# Altium schematic sheet Driver.SchDoc
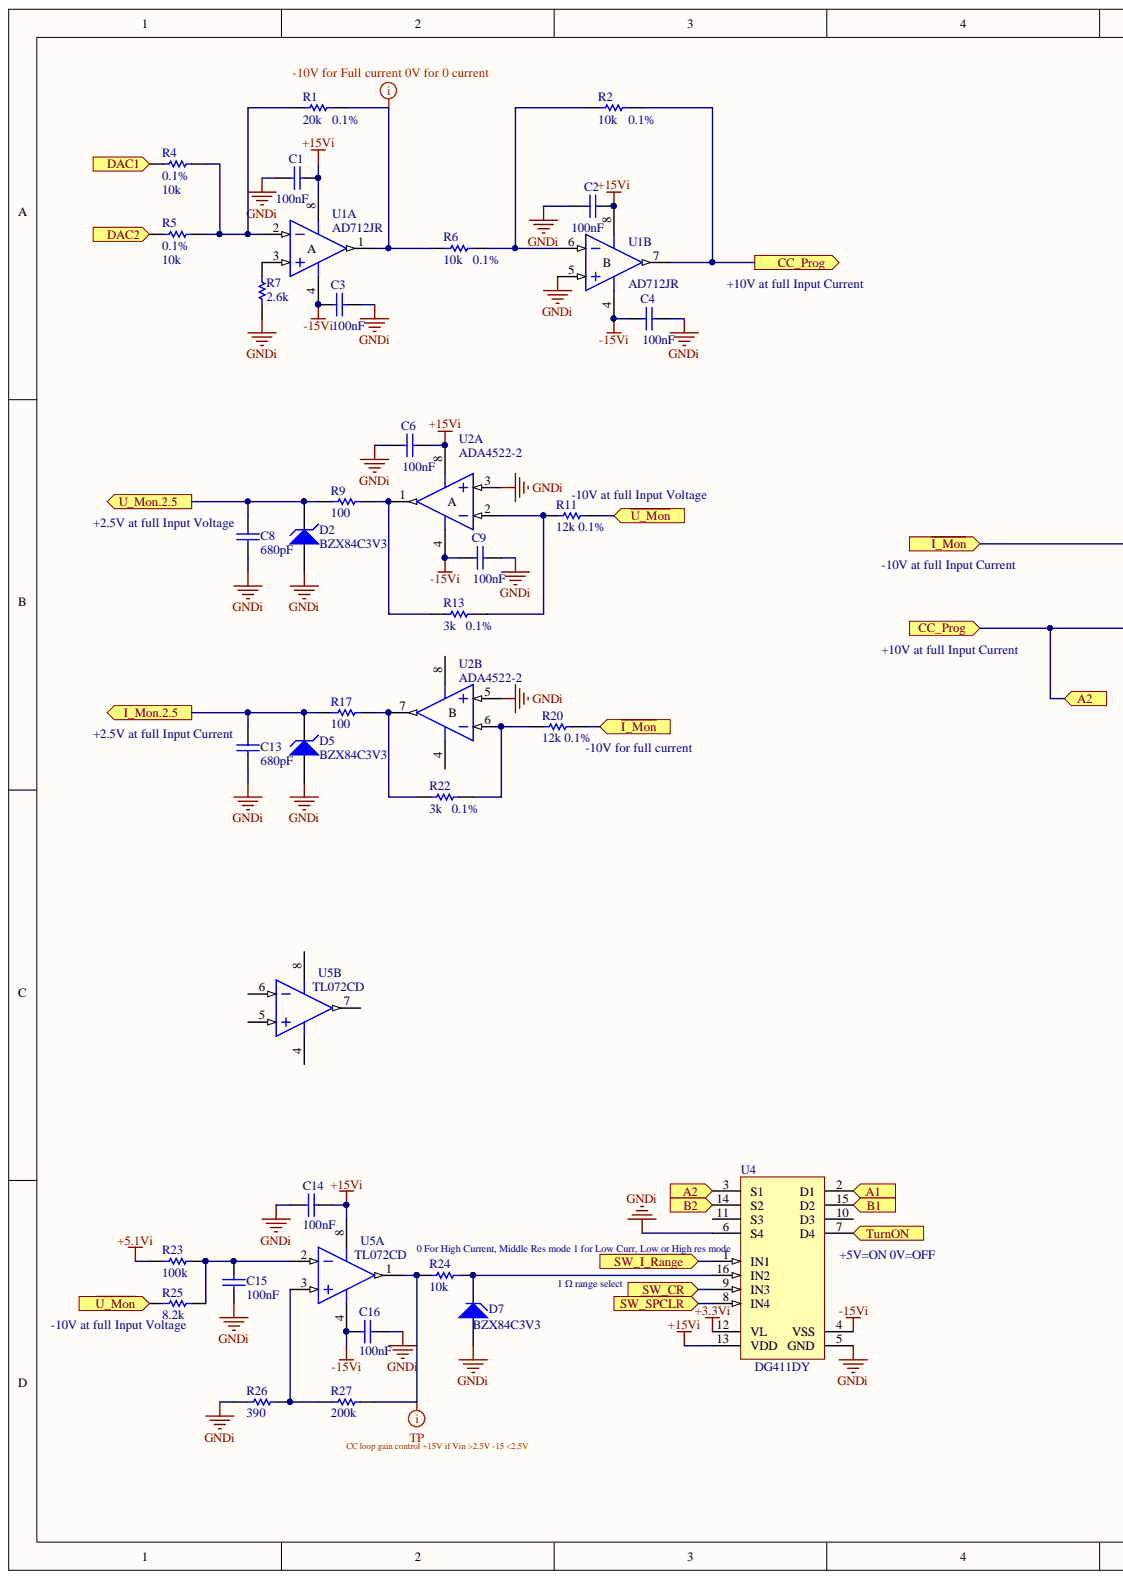
[diagram p0: Driver.SchDoc, left]
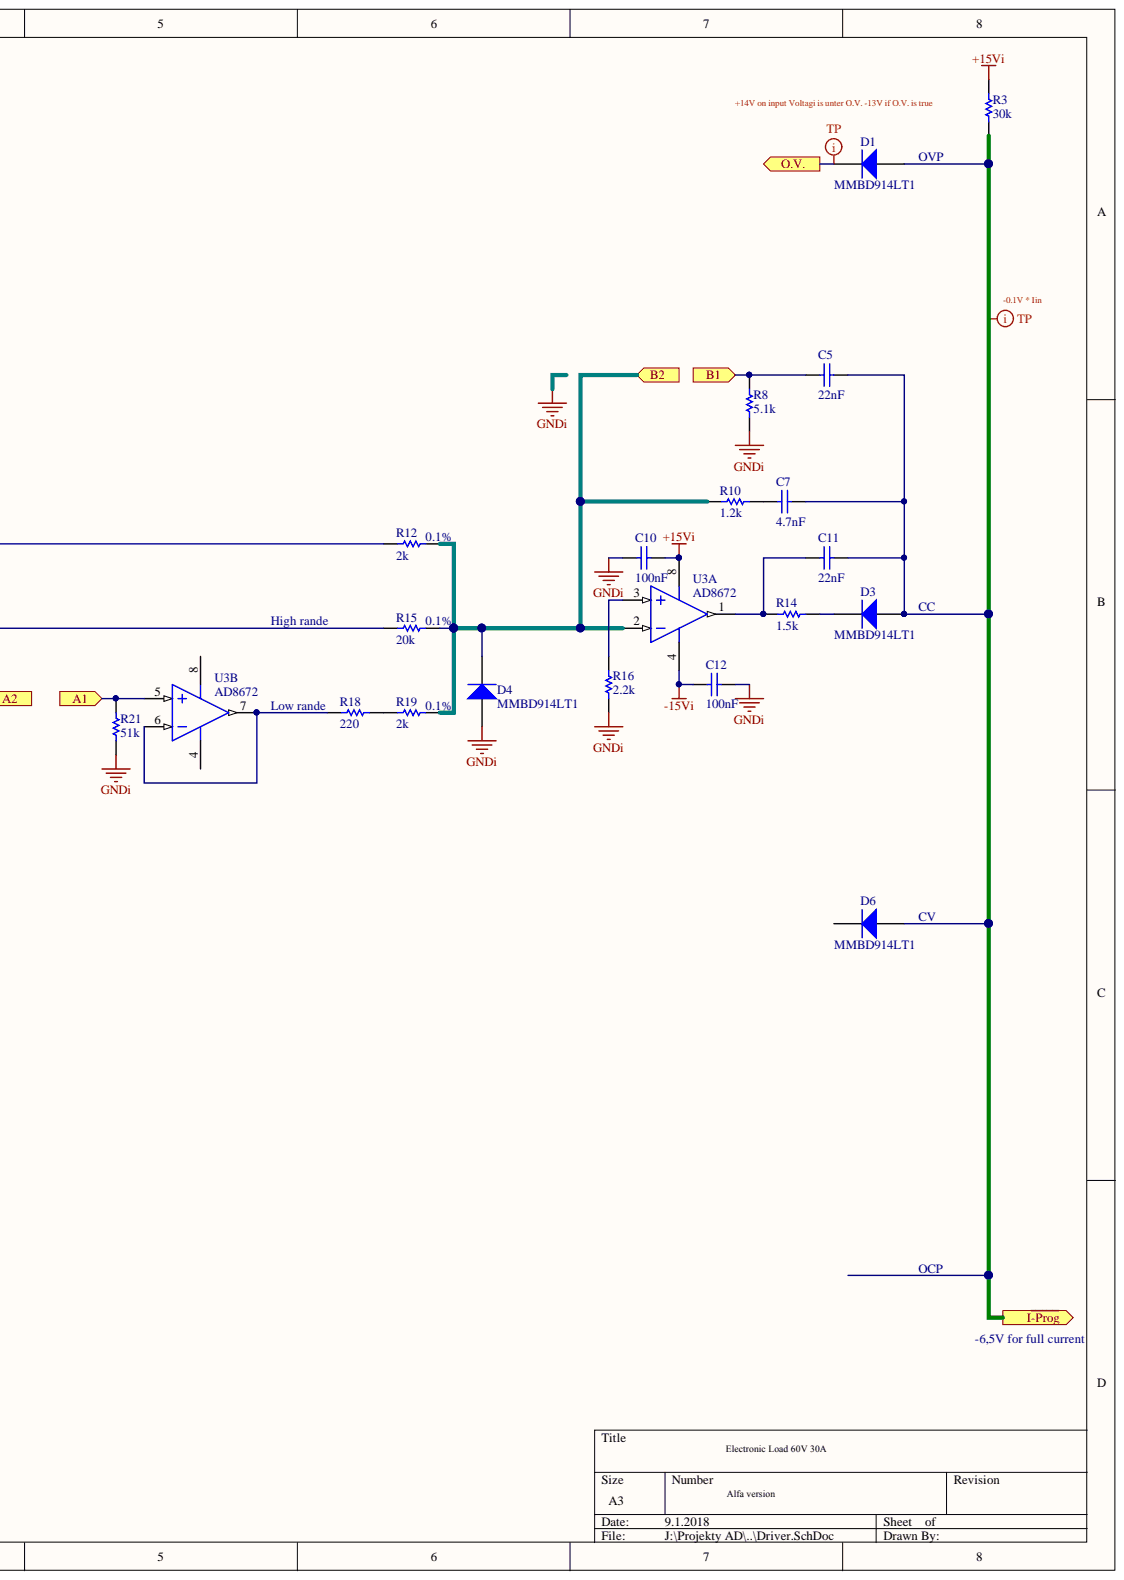
[diagram p1: Driver.SchDoc, right]

## Parts
C1  value 100nF  [1608[0603] - C]  (hidden comment: Cap)
C2  value 100nF  [1608[0603] - C]  (hidden comment: Cap)
C3  value 100nF  [1608[0603] - C]  (hidden comment: Cap)
C4  value 100nF  [1608[0603] - C]  (hidden comment: Cap)
C5  value 22nF  [2012[0805]-C]
C6  value 100nF  [1608[0603] - C]  (hidden comment: Cap)
C7  value 4.7nF  [2012[0805]-C]
C8  value 680pF  [2012[0805]-C]
C9  value 100nF  [1608[0603] - C]  (hidden comment: Cap)
C10  value 100nF  [1608[0603] - C]  (hidden comment: Cap)
C11  value 22nF  [2012[0805]-C]
C12  value 100nF  [1608[0603] - C]  (hidden comment: Cap)
C13  value 680pF  [2012[0805]-C]
C14  value 100nF  [1608[0603] - C]  (hidden comment: Cap)
C15  value 100nF  [1608[0603] - C]  (hidden comment: Cap)
C16  value 100nF  [1608[0603] - C]  (hidden comment: Cap)
D1  MMBD914LT1  [SOT23-1]
D2  BZX84C3V3  [SOT23-1]
D3  MMBD914LT1  [SOT23-1]
D4  MMBD914LT1  [SOT23-1]
D5  BZX84C3V3  [SOT23-1]
D6  MMBD914LT1  [SOT23-1]
D7  BZX84C3V3  [SOT23-1]
R1  0.1%  value 20k  [2012[0805]-R]
R2  0.1%  value 10k  [2012[0805]-R]
R3  1%  value 30k  [2012[0805]-R]
R4  0.1%  value 10k  [2012[0805]-R]
R5  0.1%  value 10k  [2012[0805]-R]
R6  0.1%  value 10k  [2012[0805]-R]
R7  value 2.6k  [2012[0805]-R]  (hidden comment: 1%)
R8  value 5.1k  [2012[0805]-R]  (hidden comment: 1%)
R9  1%  value 100  [2012[0805]-R]
R10  1%  value 1.2k  [2012[0805]-R]
R11  0.1%  value 12k  [2012[0805]-R]
R12  0.1%  value 2k  [2012[0805]-R]
R13  0.1%  value 3k  [2012[0805]-R]
R14  1%  value 1.5k  [2012[0805]-R]
R15  0.1%  value 20k  [2012[0805]-R]
R16  value 2.2k  [2012[0805]-R]  (hidden comment: 1%)
R17  1%  value 100  [2012[0805]-R]
R18  value 220  [2012[0805]-R]  (hidden comment: 0.1%)
R19  0.1%  value 2k  [2012[0805]-R]
R20  0.1%  value 12k  [2012[0805]-R]
R21  value 51k  [2012[0805]-R]  (hidden comment: 1%)
R22  0.1%  value 3k  [2012[0805]-R]
R23  1%  value 100k  [2012[0805]-R]
R24  1%  value 10k  [2012[0805]-R]
R25  1%  value 8.2k  [2012[0805]-R]
R26  value 390  [2012[0805]-R]  (hidden comment: 1%)
R27  1%  value 200k  [2012[0805]-R]
U1  AD712JR  [SO8]  (parts A,B)
U2  ADA4522-2  [SO8_L]  (parts A,B)
U3  AD8672  [so8]  (parts A,B)
U4  DG411DY  [SO-16]
U5  TL072CD  [so8]  (parts A,B)

## Ports
A1, A2, B1, B2, CC_Prog, DAC1, DAC2, I_Mon.2.5, I~{-Prog}, O.V., SW_CR, SW_I_Range, SW~{_SPCLR}, TurnON, U_Mon.2.5, ~{I_Mon}, ~{U_Mon}

## Nets
+3.3Vi: U4.12
+5.1Vi: R23.2
+15Vi: C1.2 C2.2 C6.2 C10.1 C14.1 R3.2 U1.8 U2.8 U3.8 U4.13 U5.8
-15Vi: C3.1 C4.1 C9.1 C12.2 C16.2 U1.4 U2.4 U3.4 U4.4 U5.4
GNDi: C1.1 C2.1 C3.2 C4.2 C6.1 C8.1 C9.2 C10.2 C12.1 C13.1 C14.2 C15.2 C16.1 D2.1 D2.2 D4.1 D5.1 D5.2 D7.1 R7.2 R8.2 R16.2 R21.2 R26.2 U1.5 U2.3 U2.5 U4.5 U4.6
I~{-Prog}: C5.2 C7.2 C11.2 D1.1 D3.1 D6.1 R3.1 ; port I~{-Prog}
~{I_Mon}: R12.2 R20.1 ; port ~{I_Mon}
~{U_Mon}: R11.1 R25.2 ; port ~{U_Mon}
A1: R21.1 U3.5 U4.2 ; port A1
A2: R2.1 R15.2 U1.7 U4.3 ; port A2, port CC_Prog
B1: C5.1 R8.1 U4.15 ; port B1
B2: D4.3 R10.2 R12.1 R15.1 R19.1 U3.2 U4.14 ; port B2
DAC1: R4.2 ; port DAC1
DAC2: R5.2 ; port DAC2
I_Mon.2.5: C13.2 D5.3 R17.2 ; port I_Mon.2.5
O.V.: D1.3 ; port O.V.
SW~{_SPCLR}: U4.8 ; port SW~{_SPCLR}
SW_CR: U4.9 ; port SW_CR
SW_I_Range: U4.1 ; port SW_I_Range
TurnON: U4.7 ; port TurnON
U_Mon.2.5: C8.2 D2.3 R9.2 ; port U_Mon.2.5
NetC7_1: C7.1 R10.1
NetC11_1: C11.1 R14.2 U3.1
NetC15_1: C15.1 R23.1 R25.1 U5.2
NetD3_3: D3.3 R14.1
NetD7_3: D7.3 R24.1 U4.16
NetR1_1: R1.1 R6.2 U1.1
NetR1_2: R1.2 R4.1 R5.1 U1.2
NetR2_2: R2.2 R6.1 U1.6
NetR7_1: R7.1 U1.3
NetR9_1: R9.1 R13.2 U2.1
NetR11_2: R11.2 R13.1 U2.2
NetR16_1: R16.1 U3.3
NetR17_1: R17.1 R22.2 U2.7
NetR18_1: R18.1 R19.2
NetR18_2: R18.2 U3.6 U3.7
NetR20_2: R20.2 R22.1 U2.6
NetR24_2: R24.2 R27.1 U5.1
NetR26_1: R26.1 R27.2 U5.3

Not connected: D6 3; U4 10 11; U5 5 6 7

## Library data of the parts
C1 = Cap: Capacitor; Value: 100nF; Component Kind: Standard; LatestRevisionDate: 17-Jul-2002; LatestRevisionNote: Re-released for DXP Platform.; Library Name: Miscellaneous Devices.IntLib; PackageReference: RAD-0.3; Published: 8-Jun-2000; Signal Integrity: Cap; Simulation: CAP; Publisher: Altium Limited
C2-C16 = Cap: Capacitor; Component Kind: Standard; LatestRevisionDate: 17-Jul-2002; LatestRevisionNote: Re-released for DXP Platform.; Library Name: Miscellaneous Devices.IntLib; PackageReference: RAD-0.3; Published: 8-Jun-2000; Signal Integrity: Cap; Simulation: CAP; Publisher: Altium Limited
D1 D3 D4 D6 = MMBD914LT1: High-Speed Switching Diode; Note: Pin 2 is not connected and not drawn.; Component Kind: Standard; ComponentLink1Description: Manufacturer Link; ComponentLink1URL: http://www.onsemi.com/site/products/landing?taxonomyID=194; ComponentLink2Description: Datasheet; ComponentLink2URL: http://www.onsemi.com/pub/Collateral/MMBD914LT1-D.PDF; DatasheetVersion: 1997; LatestRevisionDate: 17-Jul-2002; LatestRevisionNote: Re-released for DXP Platform.; PackageDescription: SOT-23; 3 Leads; Body 3.0 x 2.6 mm, inc. leads (LxW max); PackageReference: 318-08; PackageVersion: 1997; Published: 29-Sep-2000; Signal Integrity: MMBD914LT1; Library Name: ADUClib.SCHLIB; Publisher: Altium Limited
D2 D5 = BZX84C3V0LT1: Zener Voltage Regulator Diode; Note: Pin 2 is not connected and not drawn.; Component Kind: Standard; ComponentLink1Description: Manufacturer Link; ComponentLink1URL: http://www.onsemi.com/site/products/landing?taxonomyID=194; ComponentLink2Description: Datasheet; ComponentLink2URL: http://www.onsemi.com/pub/Collateral/BZX84C2V4LT1-D.PDF; LatestRevisionDate: 17-Jul-2002; LatestRevisionNote: Re-released for DXP Platform.; Library Name: Motorola Discrete Diode.IntLib; PackageDescription: SOT-23; 3 Leads; Body 3.0 x 2.5 mm, inc. leads (LxW max); PackageReference: 318-07; Price: 0,51; Published: 29-Sep-2000; Signal Integrity: BZX84C3V0LT1; Simulation: BZX84C3V0LT1; Supplier 1: TME; Supplier Part Number 1: BZX84C3V3; Publisher: Altium Limited
D7 = Diode ZD: Code_JEDEC: DO-214-AB; Component Kind: Standard; Datasheet: http://www.datasheetcatalog.org/datasheet/ChenyiElectronics/mXrztuu.pdf; LatestRevisionDate: 17-Jul-2002; LatestRevisionNote: Re-released for DXP Platform.; PackageDocument: Sep-1996; PackageReference: SMC; Published: 8-Jun-2000; Signal Integrity: Diode; Simulation: DIODE; Library Name: ADUClib.SCHLIB; Publisher: Altium Limited
R1-R27 = ERJ6GE: Thick Film Chip Resistor, 1 Ohm to 10M Ohm Range, 5% Tolerance, 0805 Size, 0.125 W; Note: If flow soldering, adjust pad width of PCB Footprint to 0.7 - 0.8 times the width of the resistor.; Code_IPC: 2012 [0805]; Component Kind: Standard; ComponentLink1Description: Manufacturer Link; ComponentLink1URL: http://www.panasonic.com/industrial/components/resistive_products.htm; ComponentLink2Description: Datasheet; ComponentLink2URL: http://www.panasonic.com/industrial/components/pdf/AOA0000CE1.pdf; LatestRevisionDate: 19-Mar-2004; LatestRevisionNote: Silkscreen size reduced.; Library Name: Panasonic Resistor.IntLib; PackageDocument: Mar-2003; PackageReference: 6-0805; Published: 17-Dec-2003; Signal Integrity: Res; Simulation: RESISTOR; Publisher: Altium Limited
  R4 R5: Supplier 1: Farnell; Supplier Part Number 1: 1653305
U1 = AD712JR: Dual Precision Low Cost High-Speed BiFET Operational Amplifier; Code_IPC: SOIC127P600-8; Code_JEDEC: MS-012-AA; Component Kind: Standard; ComponentLink1Description: Manufacturer Link; ComponentLink1URL: http://www.analog.com/en/prod/0,,759_786_AD712%2C00.html; ComponentLink2Description: Datasheet; ComponentLink2URL: http://www.analog.com/UploadedFiles/Data_Sheets/288953399AD712_e.pdf; DatasheetVersion: REV. B 1998; LatestRevisionDate: 13-Apr-2006; LatestRevisionNote: IPC-7351 Footprint Added.; Library Name: AD Operational Amplifier.IntLib; PackageDescription: 8-Pin Narrow Body Small Outline Integrated Circuit 1.27 mm Pitch; PackageReference: R-8; PackageVersion: 2005; PCB3D: SO-8; Price: 63; Published: 8-Jun-2000; Simulation: AD712J; Supplier 1: ebay; Supplier Part Number 1: http://www.ebay.com/itm/2-PCS-AD712JRZ-SOP-8-AD712JR-AD712-High-Speed-BiFET-Op-Amp-/122046158750?hash=item1c6a84979e:g:gHYAAOSwsTxXiJ2F; Publisher: Altium Limited
U2 = ADA4522-2: 55 V, EMI Enhanced, Zero Drift, Ultralow Noise,  Rail-to-Rail Output Operational Amplifiers; Component Kind: Standard; ComponentLink1Description: Manufacturer Link; ComponentLink1URL: http://www.analog.com/en/products/amplifiers/operational-amplifiers/zero-drift-amplifiers/ada4522-4.html; ComponentLink2Description: Datasheet; ComponentLink2URL: http://www.analog.com/media/en/technical-documentation/data-sheets/ADA4522-1_4522-2_4522-4.pdf; DatasheetVersion: REV. A 1997; LatestRevisionDate: 04-Mar-2005; LatestRevisionNote: Stylized 3D Model Added.; PackageDescription: SOIC; 14 Leads; PackageReference: N-14; PackageVersion: 1999; Published: 8-Jun-2000; Library Name: ADUClib.SCHLIB; Publisher: Altium Limited
U3 = AD8672: Dual Precision Very Low Noise, Low Input Bias Current Operational Amplifier; Code_IPC: SOIC127P600-8; Code_JEDEC: MS-012-AA; Component Kind: Standard; ComponentLink1Description: Manufacturer Link; ComponentLink1URL: http://www.analog.com/en/amplifiers-and-comparators/operational-amplifiers-op-amps/ad8672/products/product.html; DatasheetVersion: 2010; LatestRevisionDate: 13-Jun-2010; LatestRevisionNote: IPC-7351 Footprint Added.; PackageDescription: 8-Pin Small Outline Integrated Circuit 1.27 mm Pitch; PackageReference: 751-02; PCB3D: 751-02; Published: 17-Jul-2002; Library Name: ADUClib.SCHLIB; Publisher: CD Ltd.
U4 = ADG211AKR: LC2MOS Quad SPST Switches, 115 Ohm Ron, 16-pin SOIC (R-16), Tube; Manufacturer: Analog Devices; # Sw Functions: 4; Max Neg Supply: -15V; Max Pos Supply: +15V; Code_JEDEC: MS-012-AC; Component Kind: Standard; ComponentLink1Description: Manufacturer URL; ComponentLink1URL: http://www.analog.com/en/index.html; ComponentLink2Description: Datasheet; ComponentLink2URL: http://www.analog.com/static/imported-files/data_sheets/ADG211A_212A.pdf; ComponentLink3Description: Package Specification; ComponentLink3URL: http://www.analog.com/static/imported-files/packages/PKG_PDF/SOIC_Narrow%20(R)/R_16.pdf; Configuration: SPST; DatasheetVersion: Rev. C; Library Name: Analog Devices Switches and Multiplexers Analog Switches.IntLib; Mounting Technology: Surface Mount; PackageDescription: 16-Pin Standard Small Outline Package, Body 9.9 x 3.9 mm, Pitch 1.27 mm; PackageReference: R-16; PackageVersion: 060606-A, 06/2006; Packing: Tube; PartNumber: ADG211AKR; Supplier 1: Digi-Key; Supplier 2: Mouser; Supplier Part Number 1: ADG211AKR-ND; Supplier Part Number 2: 584-ADG211AKR
U5 = TL072CD: Dual Low-Noise JFET-Input General-Purpose Operational Amplifier, 7 to 36 V, 0 to 70 degC, 8-pin SOIC (D8), Green (RoHS & no Sb/Br); Manufacturer: Texas Instruments; CMRR(Min)(dB): 70; GBW(Typ)(MHz): 3; IIB(Max)(pA): 200; Iq per channel(Max)(mA): 2.5; Number of Channels: 2; Offset Drift(Typ)(uV/C): 18; Rating: Catalog; Slew Rate(Typ)(V/us): 13; Sub Family: General Purpose Amplifier, High Supply Voltage Amplifier (>=30V); Total Supply Voltage(Max)(+5V is 5, +/-5V is 10): 36; Total Supply Voltage(Min)(+5V is 5, +/-5V is 10): 7; Vio (25C)(Max)(mV): 10; Code_JEDEC: MS-012; Component Kind: Standard; ComponentLink1Description: Manufacturer URL; ComponentLink1URL: http://www.ti.com/; ComponentLink2Description: Datasheet; ComponentLink2URL: http://www.ti.com/lit/ds/symlink/tl072.pdf; ComponentLink3Description: Package Specification; ComponentLink3URL: http://www.ti.com/litv/pdf/msoi002j; DatasheetVersion: SLOS080J; Eco-Plan: Green (RoHS & no Sb/Br); Library Name: TI Amplifiers and Linear Operational Amplifier General Purpose.IntLib; Mounting Technology: Surface Mount; PackageDescription: 8-Pin Plastic Small Outline, Body 4.9 x 3.9 mm, Pitch 1.27 mm; PackageReference: D8; PackageVersion: 4040047-3/M, 06/2011; PartNumber: TL072CD; Supplier 1: Digi-Key; Supplier 2: Farnell; Supplier 3: Newark; Supplier 4: Mouser; Supplier Part Number 1: 296-1282-5-ND; Supplier Part Number 2: 1103006; Supplier Part Number 3: 08F9277; Supplier Part Number 4: 595-TL072CD; Vn at 1kHz(Typ)(nV/rtHz): 18
